# Altium schematic sheet CH32F103C8T6.SchDoc
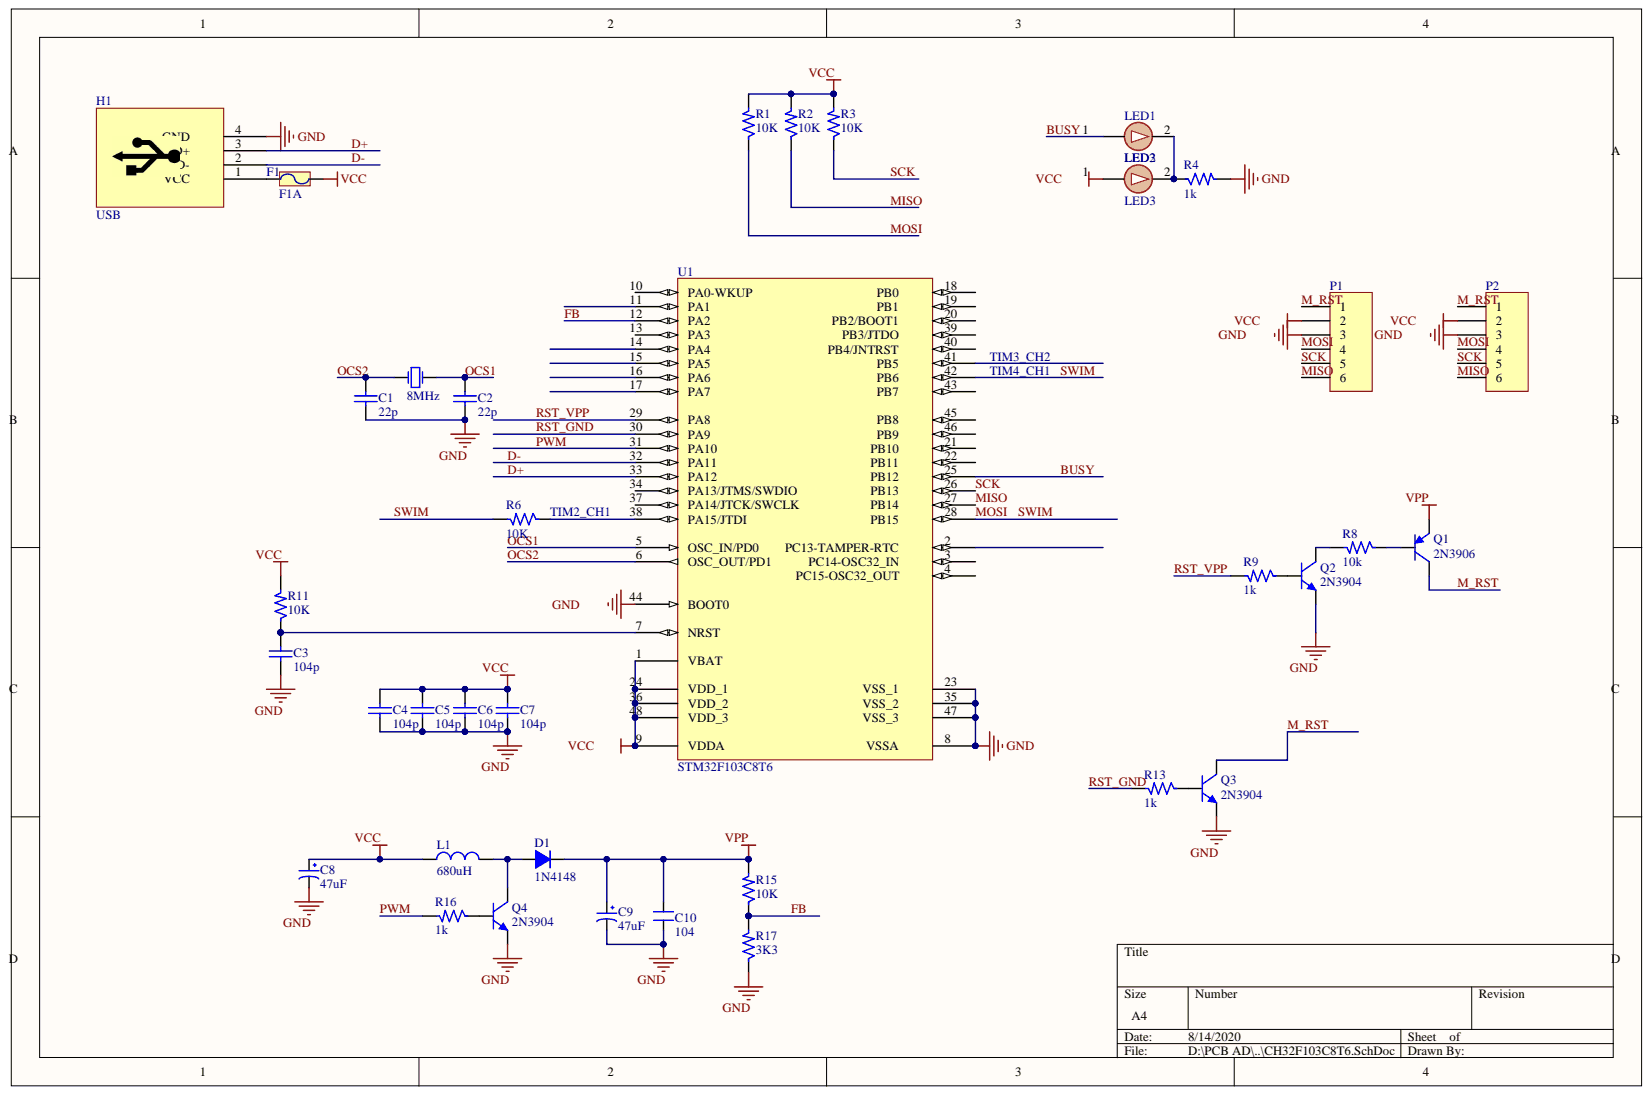
[diagram p0: CH32F103C8T6.SchDoc]

## Parts
C1  value 22p  [SM-0603-C]  (hidden comment: Capacitor Ceramic)
C2  value 22p  [SM-0603-C]  (hidden comment: Capacitor Ceramic)
C3  value 104p  [SM-0603-C]  (hidden comment: Capacitor Ceramic)
C4  value 104p  [SM-0603-C]  (hidden comment: Capacitor Ceramic)
C5  value 104p  [SM-0603-C]  (hidden comment: Capacitor Ceramic)
C6  value 104p  [SM-0603-C]  (hidden comment: Capacitor Ceramic)
C7  value 104p  [SM-0603-C]  (hidden comment: Capacitor Ceramic)
C8  47uF  [3528 MANU]
C9  47uF  [3528 MANU]
C10  104  [SM-0603-C]
D1  1N4148  [SOD-323]
F1  F1A  [SM-1206-FUSE]
H1  USB  [USB Male SMD_NHC]
L1  680uH  [INDUCTOR NLV32T MANU]
LED1  LED3  [SM-0603-LED]
LED2  LED3  [SM-0603-LED]
P1  Header 6  [HDR_1x6_H]  (value not drawn)
P2  Header 6  [HDR_1x6_V]  (value not drawn)
Q1  2N3906  [SOT23C]
Q2  2N3904  [SOT23C]
Q3  2N3904  [SOT23C]
Q4  2N3904  [SOT23C]
R1  10K  [SM-0603-R]
R2  10K  [SM-0603-R]
R3  10K  [SM-0603-R]
R4  1k  [SM-0603-R]
R6  10K  [SM-0603-R]
R8  10k  [SM-0603-R]
R9  1k  [SM-0603-R]
R11  10K  [SM-0603-R]
R13  1k  [SM-0603-R]
R15  10K  [SM-0603-R]
R16  1k  [SM-0603-R]
R17  3K3  [SM-0603-R]
U1  STM32F103C8T6  [LQFP48_M]
Y1  8MHz  [XT SMD_5032]

## Nets
GND: C1.1 C2.1 C3.1 C4.1 C5.1 C6.1 C7.1 C8.2 C9.2 C10.1 H1.4 P1.3 P2.3 Q2.1 Q3.1 Q4.1 R4.2 R17.1 U1.8 U1.23 U1.35 U1.44(BOOT0) U1.47
VCC: C4.2 C5.2 C6.2 C7.2 C8.1 F1.2 L1.1 LED2.1 P1.2 P2.2 R1.2 R2.2 R3.2 R11.2 U1.1(VBAT) U1.9(VDDA) U1.24(VDD_1) U1.36(VDD_2) U1.48(VDD_3)
VPP: C9.1 C10.2 D1.2 Q1.1 R15.2
BUSY: LED1.1 U1.25
D+: H1.3 U1.33(PA12)
D-: H1.2 U1.32(PA11)
FB: R15.1 R17.2 U1.12(PA2)
M_RST: P1.1 P2.1 Q1.3 Q3.3
MISO: P1.6 P2.6 R2.1 U1.27
MOSI: P1.4 P2.4 R1.1 R6.2 U1.28 U1.42
OCS1: C2.2 U1.5(OSC_IN/PD0) Y1.2
OCS2: C1.2 U1.6(OSC_OUT/PD1) Y1.1
PWM: R16.2 U1.31(PA10)
RST_GND: R13.2 U1.30(PA9)
RST_VPP: R9.2 U1.29(PA8)
SCK: P1.5 P2.5 R3.1 U1.26
NetC3_2: C3.2 R11.1 U1.7(NRST)
NetD1_1: D1.1 L1.2 Q4.3
NetF1_1: F1.1 H1.1
NetLED1_2: LED1.2 LED2.2 R4.1
NetQ1_2: Q1.2 R8.1
NetQ2_2: Q2.2 R9.1
NetQ2_3: Q2.3 R8.2
NetQ3_2: Q3.2 R13.1
NetQ4_2: Q4.2 R16.1
NetR6_1: R6.1 U1.38(PA15/JTDI)

Not connected: U1 2 3 4 10(PA0-WKUP) 11(PA1) 13(PA3) 14(PA4) 15(PA5) 16(PA6) 17(PA7) 18 19 20 21 22 34(PA13/JTMS/SWDIO) 37(PA14/JTCK/SWCLK) 39 40 41 43 45 46

## Library data of the parts
C1-C7 = CapCeramic: Capacitor; Component Kind: Standard; LatestRevisionDate: 17-Jul-2002; LatestRevisionNote: Re-released for DXP Platform.; PackageReference: RAD-0.3; Published: 8-Jun-2000; Library Name: kidteam_ad_SCH.SchLib; Publisher: Altium Limited
C8 C9 = CP1: Cap P  0,1uF < 22uF , 16 - 50V; Description 1: 10 => 220uF/6,3V/10V - 10uF => 100uF/16V; Description 2: 0,1uF => 33uF/50V - 10uF => 22uF/63V; Description 3: 0,47uF => 10uF/100V; Value: 10uF/50V; Component Kind: Standard; Library Name: syhaunguyen.SchLib
C10 = CM: Cap SMD; Value: 103; Component Kind: Standard; Library Name: syhaunguyen.SchLib
D1 = D1A: Diode 1A; Value: 1N4007; Component Kind: Standard; Library Name: syhaunguyen.SchLib
F1 = Fuse: Designed by: VanDungEvn; Component Kind: Standard; Description: Fuse; Value: Fuse; Library Name: kidteam_ad_SCH.SchLib
L1 = L1: Inductor; Value: 150 mH; Component Kind: Standard; Library Name: syhaunguyen.SchLib
LED1 LED2 = LED 3mm: Component Kind: Standard; Description: LED 3mm; Library Name: kidteam_ad_SCH.SchLib
P1 P2 = Header 6: Header, 6-Pin; Component Kind: Standard; LatestRevisionDate: 17-Jul-2002; LatestRevisionNote: Re-released for DXP Platform.; Signal Integrity: Connector; Library Name: kidteam_ad_SCH.SchLib; Publisher: Altium Limited
Q1 = 2N3906: PNP General Purpose Amplifier; Code_JEDEC: TO-92A; Component Kind: Standard; ComponentLink1Description: Manufacturer Link; ComponentLink1URL: http://www.fairchildsemi.com/; ComponentLink2Description: Datasheet; ComponentLink2URL: http://www.fairchildsemi.com/ds/2N%2F2N3906.pdf; DatasheetDocument: 1997; LatestRevisionDate: 15-Jan-2003; LatestRevisionNote: PCB Footprint 'BCY-W3/D4.7' replaced; PackageDocument: Sep-1998; PackageReference: TO-92A; Published: 8-Jun-2000; Signal Integrity: 2N3906; Simulation: 2N3906; Library Name: kidteam_ad_SCH.SchLib; Publisher: Altium Limited
Q2-Q4 = 2N3904: NPN General Purpose Amplifier; Code_JEDEC: TO-92A; Component Kind: Standard; ComponentLink1Description: Manufacturer Link; ComponentLink1URL: http://www.fairchildsemi.com/; ComponentLink2Description: Datasheet; ComponentLink2URL: http://www.fairchildsemi.com/ds/2N%2F2N3904.pdf; DatasheetDocument: 1997; LatestRevisionDate: 15-Jan-2003; LatestRevisionNote: PCB Footprint 'BCY-W3/D4.7' replaced; PackageDocument: Sep-1998; PackageReference: TO-92A; Published: 8-Jun-2000; Signal Integrity: 2N3904; Simulation: 2N3904; Library Name: kidteam_ad_SCH.SchLib; Publisher: Altium Limited
R1-R4 R6 R8 R9 R11 R13 R15-R17 = R: Resistor 1/4W; Value: R1/4W - 1K; Component Kind: Standard
  R1-R4 R6 R11: Library Name: kidteam_ad_SCH.SchLib
  R8 R9 R13 R15-R17: Library Name: syhaunguyen.SchLib
U1 = STM32F103C8T6: STM32 ARM-based 32-bit MCU with 64 Kbytes Flash, 48-pin LQFP, Industrial Temperature; Component Kind: Standard; ComponentLink1Description: Manufacturer Link; ComponentLink1URL: www.st.com/stm32; ComponentLink2Description: Datasheet; ComponentLink2URL: http://www.st.com/stonline/products/literature/ds/13587.pdf; DatasheetVersion: rev6, Mar-2008; Library Name: ST Microcontroller 32-Bit STM32.IntLib; PackageDescription: 48-Pin Low-Profile Quad Flat Pack, 7 x 7 mm Body, 0.5 mm Pitch (LQFP48); PackageReference: LQFP48; PackageVersion: Mar-2008; Published: 30-May-2008; Publisher: Altium Limited
Y1 = XTAL: Crystal Oscillator; Component Kind: Standard; LatestRevisionDate: 17-Jul-2002; LatestRevisionNote: Re-released for DXP Platform.; PackageReference: R38; Published: 8-Jun-2000; Library Name: kidteam_ad_SCH.SchLib; Publisher: Altium Limited
H1 = USB: Component Kind: Standard; Library Name: kidteam_ad_SCH.SchLib
